# Altium schematic sheet Sheet1.SchDoc
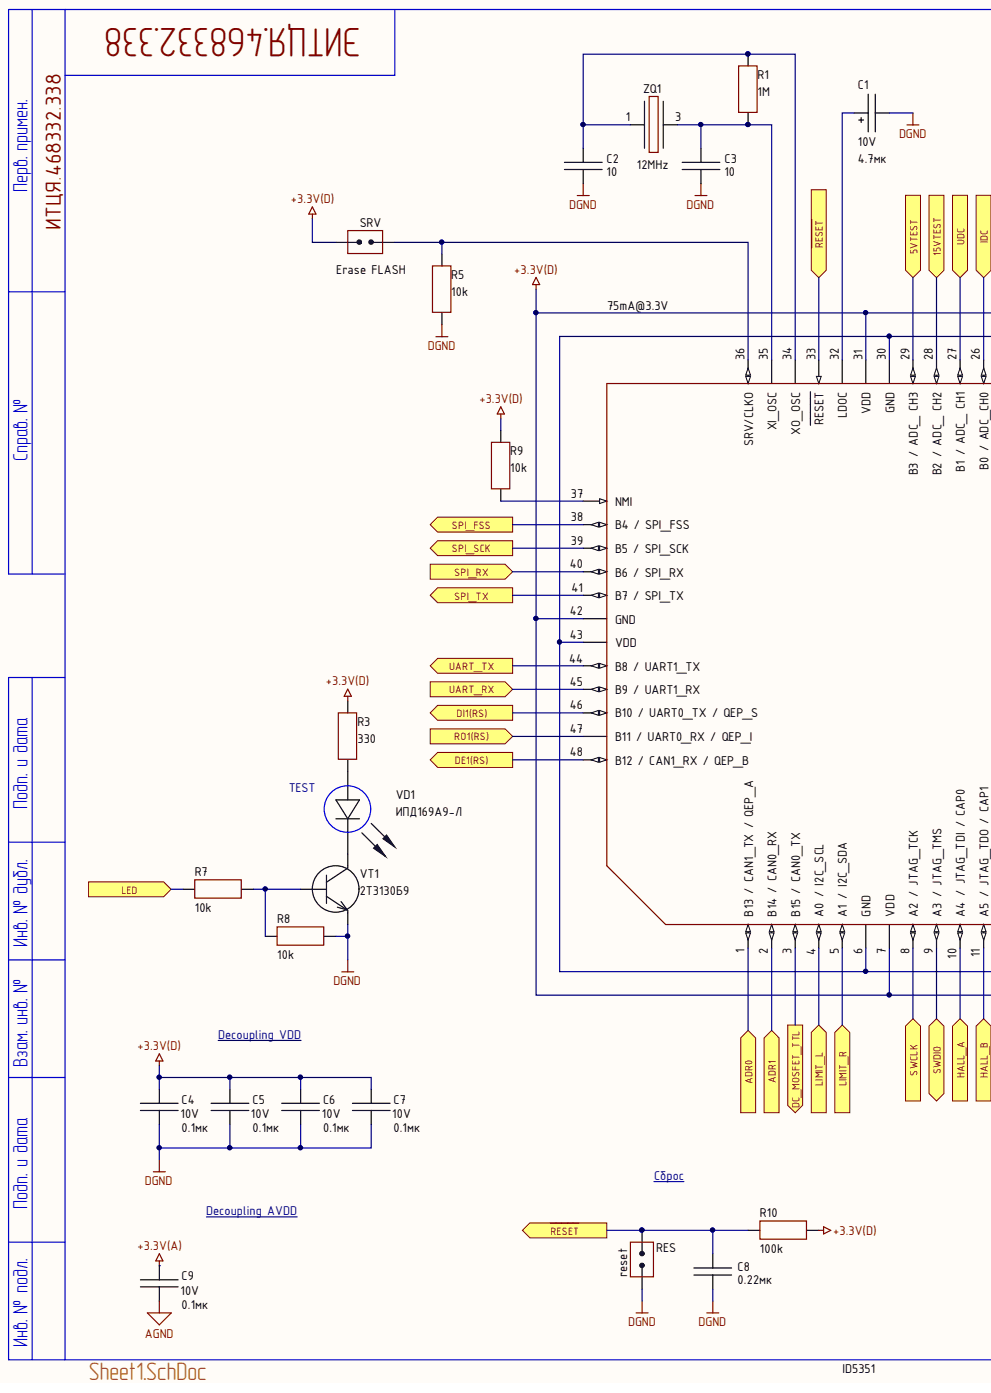
[diagram p0: Sheet1.SchDoc, left]
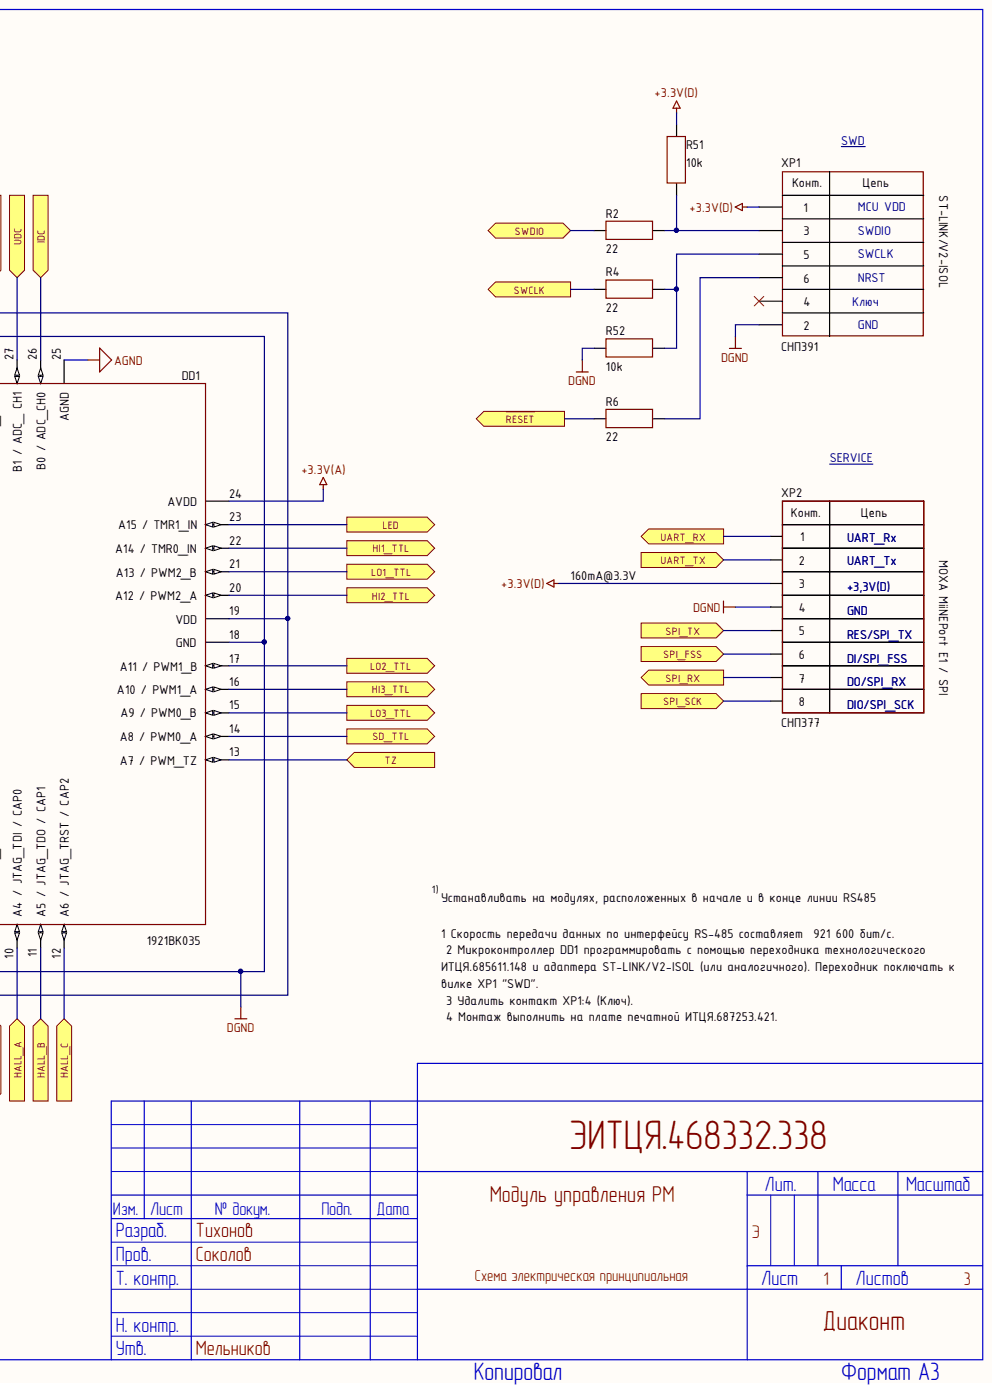
[diagram p1: Sheet1.SchDoc, right]

## Parts
C1  value 4.7мк  Voltage: 10V  [CAP: TANTAL-A]  (hidden comment: Tantalum capacitor, 4.7мк, 10V, ±10%, 2Ohm, case A (3216), -55...+125°C)
C2  value 10  [CAP: 0603P]  (hidden comment: Ceramic capacitor, 10, МП0, ±5%, 50V, 0603, -55...+125°C)
C3  value 10  [CAP: 0603P]  (hidden comment: Ceramic capacitor, 10, МП0, ±5%, 50V, 0603, -55...+125°C)
C4  value 0.1мк  Voltage: 10V  [CAP: 0603P]  (hidden comment: Ceramic capacitor, 0.1мк, H90, +80-20%, 10V, 0603, -55...+125°C)
C5  value 0.1мк  Voltage: 10V  [CAP: 0603P]  (hidden comment: Ceramic capacitor, 0.1мк, H90, +80-20%, 10V, 0603, -55...+125°C)
C6  value 0.1мк  Voltage: 10V  [CAP: 0603P]  (hidden comment: Ceramic capacitor, 0.1мк, H90, +80-20%, 10V, 0603, -55...+125°C)
C7  value 0.1мк  Voltage: 10V  [CAP: 0603P]  (hidden comment: Ceramic capacitor, 0.1мк, H90, +80-20%, 10V, 0603, -55...+125°C)
C8  value 0.22мк  [CAP: 0805]  (hidden comment: Ceramic capacitor, 0.22мк, H90, +80/-20%, 25V, 0805 (2012M), -55...+125°C)
C9  value 0.1мк  Voltage: 10V  [CAP: 0603P]  (hidden comment: Ceramic capacitor, 0.1мк, H90, +80-20%, 10V, 0603, -55...+125°C)
DD1  Motor control microcontroller, 32-Bit, RISC, 100MHz, 64KB, 16KB, 3,3 В ± 10 %., -60...+85°C, 48-QLCC (МК 5162.48-1)  [48-QLCC (МК 5162.48-1) - small pads]  (value not drawn)
R1  value 1М  [RES: 0603P]  (hidden comment: Resistor film, 1М, ±5%, 0603, 0.1W, -55...+125°C)
R2  value 22  [RES: 0603P]  (hidden comment: Resistor film, 22, ±5%, 0603, 0.1W, -55...+125°C)
R3  value 330  [RES: 0603P]  (hidden comment: Resistor film, 330, ±5%, 0603, 0.1W, -55...+125°C)
R4  value 22  [RES: 0603P]  (hidden comment: Resistor film, 22, ±5%, 0603, 0.1W, -55...+125°C)
R5  value 10k  [RES: 0603P]  (hidden comment: Resistor film, 10k, ±5%, 0603, 0.1W, -55...+125°C)
R6  value 22  [RES: 0603P]  (hidden comment: Resistor film, 22, ±5%, 0603, 0.1W, -55...+125°C)
R7  value 10k  [RES: 0603P]  (hidden comment: Resistor film, 10k, ±5%, 0603, 0.1W, -55...+125°C)
R8  value 10k  [RES: 0603P]  (hidden comment: Resistor film, 10k, ±5%, 0603, 0.1W, -55...+125°C)
R9  value 10k  [RES: 0603P]  (hidden comment: Resistor film, 10k, ±5%, 0603, 0.1W, -55...+125°C)
R10  value 100k  [RES: 0603P]  (hidden comment: Resistor film, 100k, ±5%, 0603, 0.1W, -55...+125°C)
R51  value 10k  [RES: 0603P]  (hidden comment: Resistor film, 10k, ±5%, 0603, 0.1W, -55...+125°C)
R52  value 10k  [RES: 0603P]  (hidden comment: Resistor film, 10k, ±5%, 0603, 0.1W, -55...+125°C)
RES  CommentText: reset  [CON: JUMPER x1]  (hidden comment: ValueManufacturerPartNumber)
SRV  CommentText: Erase FLASH  [CON: JUMPER x1]  (hidden comment: ValueManufacturerPartNumber)
VD1  CommentText: TEST  PartNumber: ИПД169А9-Л  [LED: 0603G]  (hidden comment: LED, 20mA max, 2.1V typ, 1608, -55...+150°C)
VT1  PartNumber: 2Т3130Б9  [SOT23]  (hidden comment: NPN transistor, 30V, 100mA, , 300mW, 0.7V, 500, SOT-23 (КТ-46), -55...+125°C)
XP1  SWD  CommentText: СНП391  [CON: PLLD-6-S]
XP2  СНП377  [CON: PLD2-8-S]
ZQ1  Frequency: 12MHz  [OSC: 5070G]  (hidden comment: Oscilator, 12MHz, CMOS, ±30ppm, case 5070, -40...+85°C)

## Ports
5VTEST, 15VTEST, ADR0, ADR1, DC_MOSFET_TTL, DE1(RS), DI1(RS), HALL_A, HALL_B, HALL_C, HI1_TTL, HI2_TTL, HI3_TTL, IDC, LED, LIMIT_L, LIMIT_R, LO1_TTL, LO2_TTL, LO3_TTL, RO1(RS), SD_TTL, SPI_FSS, SPI_RX, SPI_SCK, SPI_TX, SWCLK, SWDIO, TZ, UART_RX, UART_TX, UDC, ~{RESET}

## Nets
+3.3V(A): C9.2 DD1.24(AVDD)
+3.3V(D): C4.2 C5.2 C6.2 C7.2 DD1.7(VDD) DD1.19(VDD) DD1.31(VDD) DD1.42(GND) R3.1 R9.1 R10.2 R51.1 SRV.1 XP1.1 XP2.3
AGND: C9.1 DD1.25(AGND)
DGND: C1.2 C2.2 C3.2 C4.1 C5.1 C6.1 C7.1 C8.1 DD1.6(GND) DD1.18(GND) DD1.30(GND) DD1.43(VDD) R5.2 R8.1 R52.1 RES.1 VT1.2 XP1.2 XP2.4
5VTEST: DD1.29(CH3) ; port 5VTEST
15VTEST: DD1.28(CH2) ; port 15VTEST
~{RESET}: C8.2 DD1.33(RESET) R6.1 R10.1 RES.2 ; port ~{RESET}
ADR0: DD1.1(B13) ; port ADR0
ADR1: DD1.2(B14) ; port ADR1
DC_MOSFET_TTL: DD1.3(B15) ; port DC_MOSFET_TTL
DE1(RS): DD1.48(B12) ; port DE1(RS)
DI1(RS): DD1.46(B10) ; port DI1(RS)
HALL_A: DD1.10(A4) ; port HALL_A
HALL_B: DD1.11(A5) ; port HALL_B
HALL_C: DD1.12(A6) ; port HALL_C
HI1_TTL: DD1.22(TMR0_IN) ; port HI1_TTL
HI2_TTL: DD1.20(PWM2_A) ; port HI2_TTL
HI3_TTL: DD1.16(PWM1_A) ; port HI3_TTL
IDC: DD1.26(ADC_CH0) ; port IDC
LED: DD1.23(TMR1_IN) R7.2 ; port LED
LIMIT_L: DD1.4(A0) ; port LIMIT_L
LIMIT_R: DD1.5(A1) ; port LIMIT_R
LO1_TTL: DD1.21(PWM2_B) ; port LO1_TTL
LO2_TTL: DD1.17(PWM1_B) ; port LO2_TTL
LO3_TTL: DD1.15(PWM0_B) ; port LO3_TTL
RO1(RS): DD1.47(B11) ; port RO1(RS)
SD_TTL: DD1.14(PWM0_A) ; port SD_TTL
SPI_FSS: DD1.38(B4) XP2.6 ; port SPI_FSS
SPI_RX: DD1.40(B6) XP2.7 ; port SPI_RX
SPI_SCK: DD1.39(B5) XP2.8 ; port SPI_SCK
SPI_TX: DD1.41(B7) XP2.5 ; port SPI_TX
SWCLK: DD1.8(A2) R4.1 ; port SWCLK
SWDIO: DD1.9(A3) R2.1 ; port SWDIO
TZ: DD1.13(PWM_TZ) ; port TZ
UART_RX: DD1.45(B9) XP2.1 ; port UART_RX
UART_TX: DD1.44(B8) XP2.2 ; port UART_TX
UDC: DD1.27(CH1) ; port UDC
NetC1_1: C1.1 DD1.32(LDOC)
NetC2_1: C2.1 DD1.34(XO_OSC) R1.2 ZQ1.1
NetC3_1: C3.1 DD1.35(XI_OSC) R1.1 ZQ1.3
NetDD1_36: DD1.36(SRV/CLKO) R5.1 SRV.2
NetDD1_37: DD1.37(NMI) R9.2
NetR2_2: R2.2 R51.2 XP1.3
NetR3_2: R3.2 VD1.1
NetR4_2: R4.2 R52.2 XP1.5
NetR6_2: R6.2 XP1.6
NetR7_1: R7.1 R8.2 VT1.1
NetVD1_2: VD1.2 VT1.3

Not connected: XP1 4

## Library data of the parts
C1 = Tantal_A: Manufacturer: Элеконд; PartNumber: К53-68 "А"-10 В-4.7 мкф; MAX: 00130629; TypeDielectric: X7R; Component Kind: Standard; HelpURL: https://www.digikey.com/product-detail/en/avx-corporation/F930J107KAAAJ6/478-12404-1-ND/9645231; Impedance: 2Ohm; Library Name: Capacitor.SchLib; Package: case A (3216); Temperature: -55...+125°C; Tolerance: ±10%; TypeCapacitor: Tantalum capacitor; ValueManufacturerPartNumber: Tantalum capacitor, 4.7мк, 10V, ±10%, 2Ohm, case A (3216), -55...+125°C
C2 C3 = Ceramic_0603: Manufacturer: Монолит; PartNumber: К10-84в-1608М-50В-10 пФ ±5%-МП0-N-A; Analog: 00133079 (pin-to-pin); Component Kind: Standard; Library Name: Capacitor.SchLib; Package: 0603; Temperature: -55...+125°C; Tolerance: ±5%; TypeCapacitor: Ceramic capacitor; TypeDielectric: МП0; ValueManufacturerPartNumber: Ceramic capacitor, 10, МП0, ±5%, 50V, 0603, -55...+125°C; Voltage: 50V
C4-C7 C9 = Ceramic_0603: Manufacturer: Гириконд; PartNumber: К10-79-10В-0,1 мкФ +80-20% H90; MAX: 00131675; Component Kind: Standard; HelpURL: http://www.giricond.ru/files/k10-79_vp_2018_saiyt.pdf; Library Name: Capacitor.SchLib; Package: 0603; Temperature: -55...+125°C; Tolerance: +80-20%; TypeCapacitor: Ceramic capacitor; TypeDielectric: H90; ValueManufacturerPartNumber: Ceramic capacitor, 0.1мк, H90, +80-20%, 10V, 0603, -55...+125°C
C8 = Ceramic_5750M: Manufacturer: Монолит; PartNumber: К10-84в-1608М-25В-220 нФ +80/-20%-Н90-N-A; Analog: MAX 00131682 (pin-to-pin); Component Kind: Standard; Library Name: Capacitor.SchLib; Package: 0805 (2012M); Temperature: -55...+125°C; Tolerance: +80/-20%; TypeCapacitor: Ceramic capacitor; TypeDielectric: H90; ValueManufacturerPartNumber: Ceramic capacitor, 0.22мк, H90, +80/-20%, 25V, 0805 (2012M), -55...+125°C; Voltage: 25V
DD1 = 1921ВК035: Cortex-M4F; Manufacturer: НИИЭТ; Component Kind: Standard; ComponentType: Motor control microcontroller; CoreProcessor: RISC; CoreSize: 32-Bit; HelpURL: https://niiet.ru/goods/tranzmod/newtr; Library Name: IC. MCU.SchLib; MemoryRAM: 16KB; MemoryROM: 64KB; Package: 48-QLCC (МК 5162.48-1); PartNumber: 1921ВК035; Speed: 100MHz; Supply: 3,3 В ± 10 %.; Temperature: -60...+85°C; ValueManufacturerPartNumber: Motor control microcontroller, 32-Bit, RISC, 100MHz, 64KB, 16KB, 3,3 В ± 10 %., -60...+85°C, 48-QLCC (МК 5162.48-1)
R1 = Resistor_0603: Manufacturer: ОАО Ресурс; PartNumber: Р1-12-0,1ум.-1~МОм ±5%-М ШКАБ.434110.002 ТУ; MAX: 00133970; Component Kind: Standard; HelpURL: https://aoresurs.com/catalog/chip_rezistory/rezistory-r1-12-kategoriya-kachestva-vp-/; Library Name: Resistor.IntLib; Package: 0603; Power: 0.1W; Temperature: -55...+125°C; Tolerance: ±5%; TypeResistor: Resistor film; ValueManufacturerPartNumber: Resistor film, 1М, ±5%, 0603, 0.1W, -55...+125°C
R2 R4 R6 = Resistor_0603: Manufacturer: ОАО Ресурс; PartNumber: Р1-12-0,1ум.-22~Ом ±5%-М ШКАБ.434110.002 ТУ; MAX: 00134024; Component Kind: Standard; HelpURL: https://aoresurs.com/catalog/chip_rezistory/rezistory-r1-12-kategoriya-kachestva-vp-/; Library Name: Resistor.IntLib; Package: 0603; Power: 0.1W; Temperature: -55...+125°C; Tolerance: ±5%; TypeResistor: Resistor film; ValueManufacturerPartNumber: Resistor film, 22, ±5%, 0603, 0.1W, -55...+125°C
R3 = Resistor_0603: Manufacturer: ОАО Ресурс; PartNumber: Р1-12-0,1ум.-330~Ом ±5%-М ШКАБ.434110.002 ТУ; MAX: 00134047; Component Kind: Standard; HelpURL: https://aoresurs.com/catalog/chip_rezistory/rezistory-r1-12-kategoriya-kachestva-vp-/; Library Name: Resistor.IntLib; Package: 0603; Power: 0.1W; Temperature: -55...+125°C; Tolerance: ±5%; TypeResistor: Resistor film; ValueManufacturerPartNumber: Resistor film, 330, ±5%, 0603, 0.1W, -55...+125°C
R5 R7-R9 R51 R52 = Resistor_0603: Manufacturer: ОАО Ресурс; PartNumber: Р1-12-0,1ум.-10~кОм ±5%-М ШКАБ.434110.002 ТУ; Analog: MAX 00133985; MAX: 00133985; Component Kind: Standard; HelpURL: https://aoresurs.com/catalog/chip_rezistory/rezistory-r1-12-kategoriya-kachestva-vp-/; Library Name: Resistor.IntLib; Package: 0603; Power: 0.1W; Temperature: -55...+125°C; Tolerance: ±5%; TypeResistor: Resistor film; ValueManufacturerPartNumber: Resistor film, 10k, ±5%, 0603, 0.1W, -55...+125°C
R10 = Resistor_0603: Manufacturer: ОАО Ресурс; PartNumber: Р1-12-0,1ум.-100~кОм ±5%-М ШКАБ.434110.002 ТУ; Analog: MAX 00122851; MAX: 00133990; Component Kind: Standard; HelpURL: https://aoresurs.com/catalog/chip_rezistory/rezistory-r1-12-kategoriya-kachestva-vp-/; Library Name: Resistor.IntLib; Package: 0603; Power: 0.1W; Temperature: -55...+125°C; Tolerance: ±5%; TypeResistor: Resistor film; ValueManufacturerPartNumber: Resistor film, 100k, ±5%, 0603, 0.1W, -55...+125°C
RES = Jumper_single: Manufacturer: ОАО "Карачевский завод "Электродеталь"; PartNumber: СНП377-2ВП21-1-02-04; Analog: MAX 00148900 (PLS2-2); MAX: 00124338; Pitch: 2mm; Component Kind: Standard; HelpURL: http://www.elektrodetal.com/product/soediniteli-dlya-pechatnyh-plat/snp377.html; Library Name: Connector.SchLib; Type: штыри 2 пина в отв.
SRV = Jumper_single: Manufacturer: ОАО "Карачевский завод "Электродеталь"; PartNumber: СНП391-2ВП21-1 РЮМК.430420.036 ТУ; Analog: PLL1.27 (MAX 00150250 с доработкой до 2 пинов); Pitch: 1.27mm; Component Kind: Standard; HelpURL: http://www.elektrodetal.com/product/soediniteli-dlya-pechatnyh-plat/snp377.html; Library Name: Connector.SchLib; Type: штыри 2 пина в отв.
VD1 = LED_single: Manufacturer: Протон; Analog: MAX 00129484 КР-1608 Kingbright (pin-to-pin); Color: GREEN; Component Kind: Standard; Current: 20mA max; Library Name: Diode.SchLib; Package: 1608; Temperature: -55...+150°C; TypeDiode: LED; ValueManufacturerPartNumber: LED, 20mA max, 2.1V typ, 1608, -55...+150°C; VoltageDrop: 2.1V typ
VT1 = BJT_NPN_132: Manufacturer: ООО НПК Далекс; CurrentGain: 500; MAX: 00123842; Component Kind: Standard; CurrentCE: 100mA; HelpURL: http://www.radioman-portal.ru/sprav/pp/transisters/sourse/2t3130.pdf; Library Name: Transistor.SchLib; MaxPower: 300mW; Package: SOT-23 (КТ-46); Temperature: -55...+125°C; TypeTransistor: NPN transistor; ValueManufacturerPartNumber: NPN transistor, 30V, 100mA, , 300mW, 0.7V, 500, SOT-23 (КТ-46), -55...+125°C; VoltageCE: 30V; VoltageSaturation: 0.7V
XP1 = PLLD1.27-6_1: аналог СНП391-6ВП41-2; Manufacturer: Электроделать; PartNumber: СНП391-6ВП41-2 РЮМК.430420.036 ТУ; ValueManufacturerPartNumber: Connector, 6pin, 1.27mm, 125VAC, 1A; Analog: MAX 00130684 c доработкой до 6 пинов; CurrentMax: 1A; Multichannel: 6pin; Pitch: 1.27mm; TypeConnector: Connector; VoltageMax: 125VAC; Analog 1: PLLD1.27-6; Component Kind: Standard; HelpURL: https://www.elektrodetal.com/catalog/snp391/; Library Name: Connector.SchLib
XP2 = PLD2-8-S: СНП377-8ВП41-2 SMD; Manufacturer: ОАО "Карачевский завод "Электродеталь"; CommentText: СПН377; Pitch: 2mm; TU: РЮМК.430420.017 ТУ; Analog: PLD2-8-S; Component Kind: Standard; HelpURL: https://www.elektrodetal.com/catalog/snp377/; Library Name: Connector.SchLib; PartNumber: СНП377-8ВП41-2; Type: Вилка
ZQ1 = Oscilator_5070: Manufacturer: ОАО "Морион"; PartNumber: РК486-8ДС-12000K; MAX: 00149842; Component Kind: Standard; HelpURL: http://www.lit-phonon.ru/downloads/RK486.pdf; Library Name: Oscilator.SchLib; LoadCapacitance: CMOS; Package: case 5070; Temperature: -40...+85°C; Tolerance: ±30ppm; TypeOscilator: Oscilator; ValueManufacturerPartNumber: Oscilator, 12MHz, CMOS, ±30ppm, case 5070, -40...+85°C
